# Altium schematic sheet OUT_B1.SchDoc
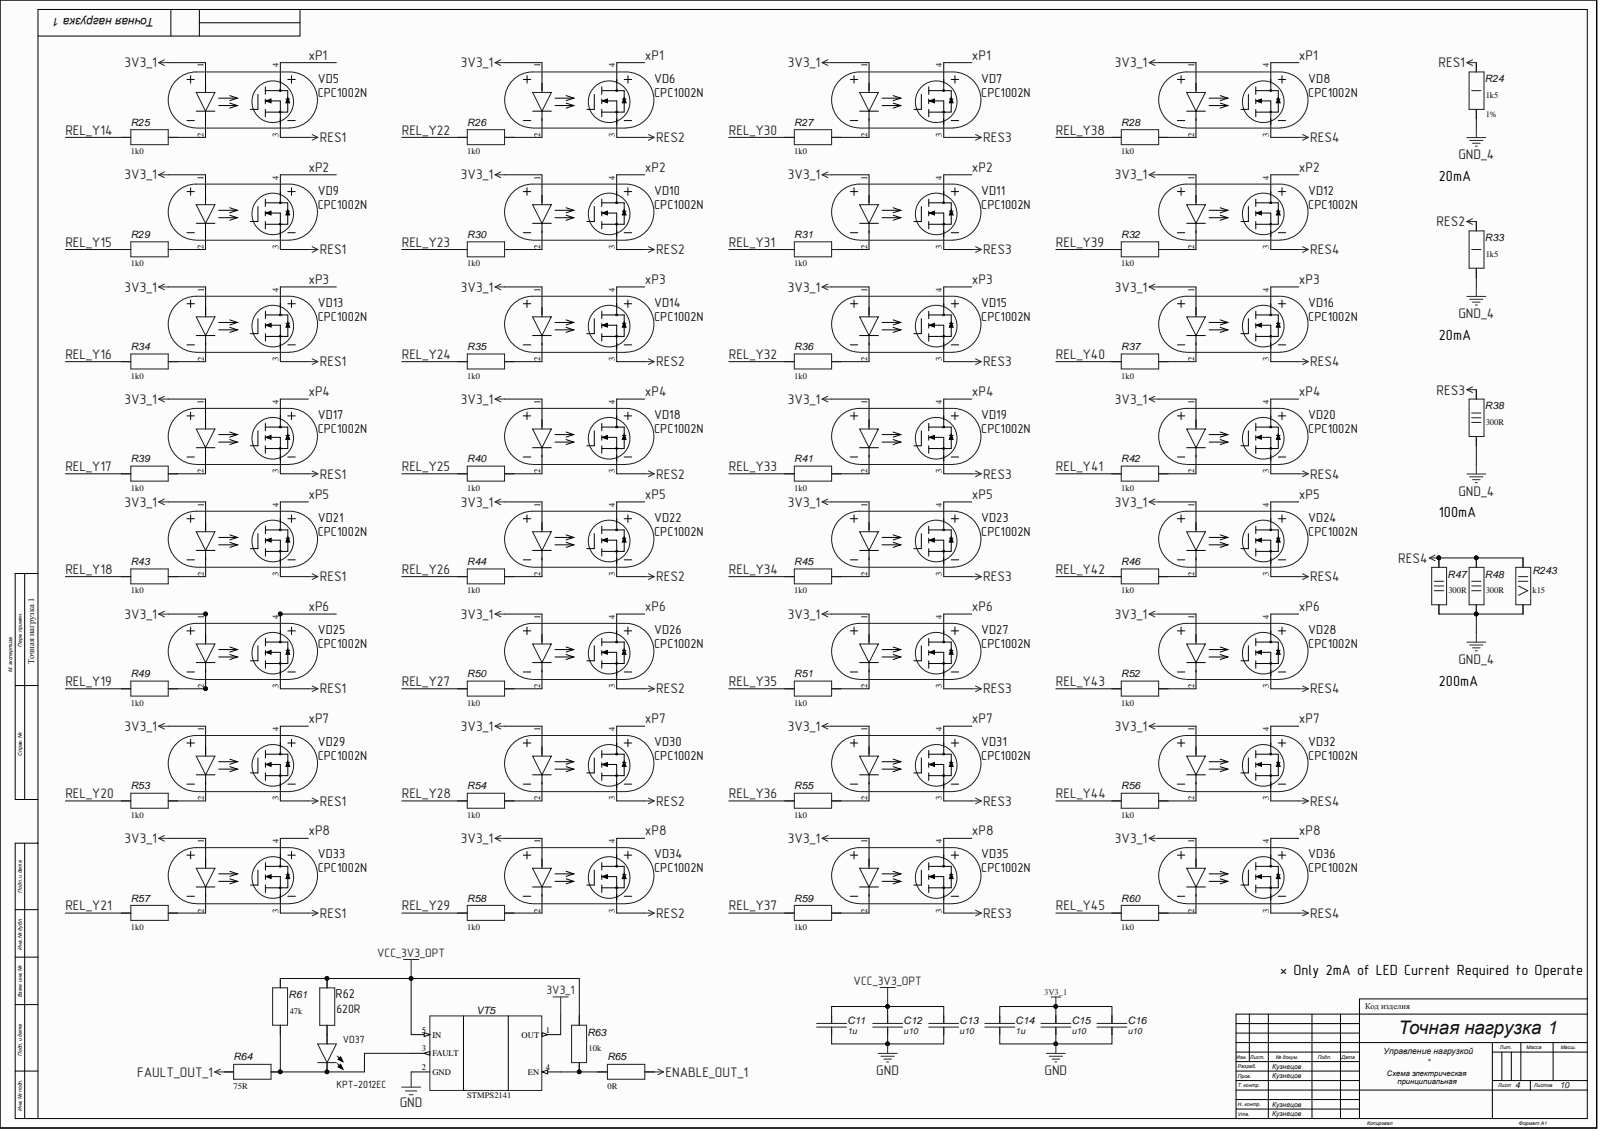
[diagram p0: OUT_B1.SchDoc]

## Parts
C11  1u
C12  u10
C13  u10
C14  1u
C15  u10
C16  u10
R24  1k5  Tolerance: 1%  [R0_6]
R25  1k0  [0805]
R26  1k0  [0805]
R27  1k0  [0805]
R28  1k0  [0805]
R29  1k0  [0805]
R30  1k0  [0805]
R31  1k0  [0805]
R32  1k0  [0805]
R33  value 1k5  [R0_6]  (hidden comment: MRS25)
R34  1k0  [0805]
R35  1k0  [0805]
R36  1k0  [0805]
R37  1k0  [0805]
R38  value 300R  [R3_0]  (hidden comment: PAC03)
R39  1k0  [0805]
R40  1k0  [0805]
R41  1k0  [0805]
R42  1k0  [0805]
R43  1k0  [0805]
R44  1k0  [0805]
R45  1k0  [0805]
R46  1k0  [0805]
R47  value 300R  [R3_0]  (hidden comment: PAC03)
R48  value 300R  [R3_0]  (hidden comment: PAC03)
R49  1k0  [0805]
R50  1k0  [0805]
R51  1k0  [0805]
R52  1k0  [0805]
R53  1k0  [0805]
R54  1k0  [0805]
R55  1k0  [0805]
R56  1k0  [0805]
R57  1k0  [0805]
R58  1k0  [0805]
R59  1k0  [0805]
R60  1k0  [0805]
R61  47k  [0805]
R62  620R  [0805]
R63  10k  [0805]
R64  75R  [0805]
R65  0R  [0805]
R243  value k15  [RH005  7,5W]  (hidden comment: RH005)
VD5  CPC1002N  [SO-4 2.54_5.60]
VD6  CPC1002N  [SO-4 2.54_5.60]
VD7  CPC1002N  [SO-4 2.54_5.60]
VD8  CPC1002N  [SO-4 2.54_5.60]
VD9  CPC1002N  [SO-4 2.54_5.60]
VD10  CPC1002N  [SO-4 2.54_5.60]
VD11  CPC1002N  [SO-4 2.54_5.60]
VD12  CPC1002N  [SO-4 2.54_5.60]
VD13  CPC1002N  [SO-4 2.54_5.60]
VD14  CPC1002N  [SO-4 2.54_5.60]
VD15  CPC1002N  [SO-4 2.54_5.60]
VD16  CPC1002N  [SO-4 2.54_5.60]
VD17  CPC1002N  [SO-4 2.54_5.60]
VD18  CPC1002N  [SO-4 2.54_5.60]
VD19  CPC1002N  [SO-4 2.54_5.60]
VD20  CPC1002N  [SO-4 2.54_5.60]
VD21  CPC1002N  [SO-4 2.54_5.60]
VD22  CPC1002N  [SO-4 2.54_5.60]
VD23  CPC1002N  [SO-4 2.54_5.60]
VD24  CPC1002N  [SO-4 2.54_5.60]
VD25  CPC1002N  [SO-4 2.54_5.60]
VD26  CPC1002N  [SO-4 2.54_5.60]
VD27  CPC1002N  [SO-4 2.54_5.60]
VD28  CPC1002N  [SO-4 2.54_5.60]
VD29  CPC1002N  [SO-4 2.54_5.60]
VD30  CPC1002N  [SO-4 2.54_5.60]
VD31  CPC1002N  [SO-4 2.54_5.60]
VD32  CPC1002N  [SO-4 2.54_5.60]
VD33  CPC1002N  [SO-4 2.54_5.60]
VD34  CPC1002N  [SO-4 2.54_5.60]
VD35  CPC1002N  [SO-4 2.54_5.60]
VD36  CPC1002N  [SO-4 2.54_5.60]
VD37  KPT-2012EC  [0805_LED_RED]
VT5  STMPS2141  [SOT-23-5]

## Nets
3V3_1: C14.2 C15.2 C16.2 VD5.1 VD6.1 VD7.1 VD8.1 VD9.1 VD10.1 VD11.1 VD12.1 VD13.1 VD14.1 VD15.1 VD16.1 VD17.1 VD18.1 VD19.1 VD20.1 VD21.1 VD22.1 VD23.1 VD24.1 VD25.1 VD26.1 VD27.1 VD28.1 VD29.1 VD30.1 VD31.1 VD32.1 VD33.1 VD34.1 VD35.1 VD36.1 VT5.1(OUT)
ENABLE_OUT_1: R65.1
FAULT_OUT_1: R64.2
GND: C11.1 C12.1 C13.1 C14.1 C15.1 C16.1 VT5.2(GND)
GND_4: R24.1 R33.1 R38.1 R47.1 R48.1 R243.1
RES1: R24.2 VD5.3 VD9.3 VD13.3 VD17.3 VD21.3 VD25.3 VD29.3 VD33.3
RES2: R33.2 VD6.3 VD10.3 VD14.3 VD18.3 VD22.3 VD26.3 VD30.3 VD34.3
RES3: R38.2 VD7.3 VD11.3 VD15.3 VD19.3 VD23.3 VD27.3 VD31.3 VD35.3
RES4: R47.2 R48.2 R243.2 VD8.3 VD12.3 VD16.3 VD20.3 VD24.3 VD28.3 VD32.3 VD36.3
VCC_3V3_OPT: C11.2 C12.2 C13.2 R61.1 R62.2 R63.1 VT5.5(IN)
REL_Y14: R25.2
REL_Y15: R29.2
REL_Y16: R34.2
REL_Y17: R39.2
REL_Y18: R43.2
REL_Y19: R49.2
REL_Y20: R53.2
REL_Y21: R57.2
REL_Y22: R26.2
REL_Y23: R30.2
REL_Y24: R35.2
REL_Y25: R40.2
REL_Y26: R44.2
REL_Y27: R50.2
REL_Y28: R54.2
REL_Y29: R58.2
REL_Y30: R27.2
REL_Y31: R31.2
REL_Y32: R36.2
REL_Y33: R41.2
REL_Y34: R45.2
REL_Y35: R51.2
REL_Y36: R55.2
REL_Y37: R59.2
REL_Y38: R28.2
REL_Y39: R32.2
REL_Y40: R37.2
REL_Y41: R42.2
REL_Y42: R46.2
REL_Y43: R52.2
REL_Y44: R56.2
REL_Y45: R60.2
xP1: VD5.4 VD6.4 VD7.4 VD8.4
xP2: VD9.4 VD10.4 VD11.4 VD12.4
xP3: VD13.4 VD14.4 VD15.4 VD16.4
xP4: VD17.4 VD18.4 VD19.4 VD20.4
xP5: VD21.4 VD22.4 VD23.4 VD24.4
xP6: VD25.4 VD26.4 VD27.4 VD28.4
xP7: VD29.4 VD30.4 VD31.4 VD32.4
xP8: VD33.4 VD34.4 VD35.4 VD36.4
NetR25_1: R25.1 VD5.2
NetR26_1: R26.1 VD6.2
NetR27_1: R27.1 VD7.2
NetR28_1: R28.1 VD8.2
NetR29_1: R29.1 VD9.2
NetR30_1: R30.1 VD10.2
NetR31_1: R31.1 VD11.2
NetR32_1: R32.1 VD12.2
NetR34_1: R34.1 VD13.2
NetR35_1: R35.1 VD14.2
NetR36_1: R36.1 VD15.2
NetR37_1: R37.1 VD16.2
NetR39_1: R39.1 VD17.2
NetR40_1: R40.1 VD18.2
NetR41_1: R41.1 VD19.2
NetR42_1: R42.1 VD20.2
NetR43_1: R43.1 VD21.2
NetR44_1: R44.1 VD22.2
NetR45_1: R45.1 VD23.2
NetR46_1: R46.1 VD24.2
NetR49_1: R49.1 VD25.2
NetR50_1: R50.1 VD26.2
NetR51_1: R51.1 VD27.2
NetR52_1: R52.1 VD28.2
NetR53_1: R53.1 VD29.2
NetR54_1: R54.1 VD30.2
NetR55_1: R55.1 VD31.2
NetR56_1: R56.1 VD32.2
NetR57_1: R57.1 VD33.2
NetR58_1: R58.1 VD34.2
NetR59_1: R59.1 VD35.2
NetR60_1: R60.1 VD36.2
NetR61_2: R61.2 R64.1 VD37.2 VT5.3(FAULT)
NetR62_1: R62.1 VD37.1
NetR63_2: R63.2 R65.2 VT5.4(EN)

## Library data of the parts
C11-C16 = (no symbol name): Capacitor; Power\Voltage: 25V; TKE: 5XR; Tolerance: 10%; Корпус: 0805; Component Kind: Standard; ComponentLink1Description: Параметры; ComponentLink2Description: Сайт производителя; Author: Eleman; Library Name: eleman_capacitor.schlib
  C11 C14: Value: 1u
  C12 C13 C15 C16: Value: u10
R24 = MRS25: Импортный постоянный углеродистый резистор; Manufacturer: Vishay Dale; ManufacturerPartNumber: MRS25000C1501FRP00; Power\Voltage: 0.6W; Корпус: Axial; Component Kind: Standard; ComponentLink1Description: Параметры; ComponentLink2Description: Сайт производителя; Value: 1k5; Author: Eleman; ComponentLink1URL: d:\YandexDisk\Project_Altium\Lib_Eleman\; Library Name: Eleman_Resistor.SchLib
R25-R32 R34-R37 R39-R46 R49-R65 = R0805: Импортный постоянный углеродистый резистор; Manufacturer: Vishay Dale; Power\Voltage: 0.125W; Tolerance: 5%; Component Kind: Standard; ComponentLink1Description: Параметры; ComponentLink2Description: Сайт производителя; ManufacturerPartNumber: R0805; Корпус: SMD 0805; Author: Eleman; Library Name: Eleman_Resistor.SchLib
  R25-R32 R34-R37 R39-R46 R49-R60: Value: 1k0
  R61: Value: 47k
  R62: Value: 620R
  R63: Value: 10k
  R64: Value: 75R
  R65: Value: 0R
R33 = MRS25: Импортный постоянный углеродистый резистор; Manufacturer: Vishay Dale; ManufacturerPartNumber: MRS25000C1501FRP00; Power\Voltage: 0.6W; Tolerance: 1%; Component Kind: Standard; ComponentLink1Description: Параметры; ComponentLink2Description: Сайт производителя; Author: Eleman; ComponentLink1URL: d:\YandexDisk\Project_Altium\Lib_Eleman\; Library Name: Eleman_Resistor.SchLib
R38 R47 R48 = PAC03: Импортный постоянный углеродистый резистор; Manufacturer: Vishay Dale; ManufacturerPartNumber: PAC300003000FAC000; Power\Voltage: 3W; Tolerance: 1%; Component Kind: Standard; ComponentLink1Description: Параметры; ComponentLink2Description: Сайт производителя; Author: Eleman; ComponentLink1URL: d:\YandexDisk\Project_Altium\Lib_Eleman\; Library Name: Eleman_Resistor.SchLib
R243 = RH005_7W: Импортный постоянный углеродистый резистор; Manufacturer: Vishay Dale; ManufacturerPartNumber: RH005_; Power\Voltage: 7W; Tolerance: 1%; Component Kind: Standard; ComponentLink1Description: Параметры; ComponentLink2Description: Сайт производителя; Author: Eleman; ComponentLink1URL: d:\YandexDisk\Project_Altium\Lib_Eleman\; Library Name: Eleman_Resistor.SchLib
VD5-VD36 = CPC1002N: Оптореле; Manufacturer: IXYS; Power\Voltage: 60VDC/0.7A; Корпус: Panel; Component Kind: Standard; ComponentLink1Description: Параметры; ComponentLink2Description: Сайт производителя; ManufacturerPartNumber: CPC1002N; Author: Eleman; ComponentLink1URL: d:\YandexDisk\Project_Altium\Lib_Eleman\; Library Name: Eleman_Opto.SchLib
VD37 = KPT-2012EC: Светодиод/Красный/Чип; Manufacturer: KINGBRIGHT; Power\Voltage: 20mA; Rem: Red; Component Kind: Standard; ComponentLink1Description: Параметры; ComponentLink2Description: Сайт производителя; ManufacturerPartNumber: KPT-2012EC; Value: KPT-2012EC; Корпус: SMD 0805; Author: Eleman; ComponentLink1URL: d:\YandexDisk\Project_Altium\Lib_Eleman\; Library Name: Eleman_Diods.SchLib
VT5 = STMPS2141: Транзистор; Корпус: SO-23-5; Component Kind: Standard; ComponentLink1Description: Параметры; ComponentLink2Description: Сайт производителя; ManufacturerPartNumber: STMPS2141; Author: Eleman; Library Name: Eleman_Driver.SchLib
